# Altium schematic sheet Interface.SchDoc(Interface)
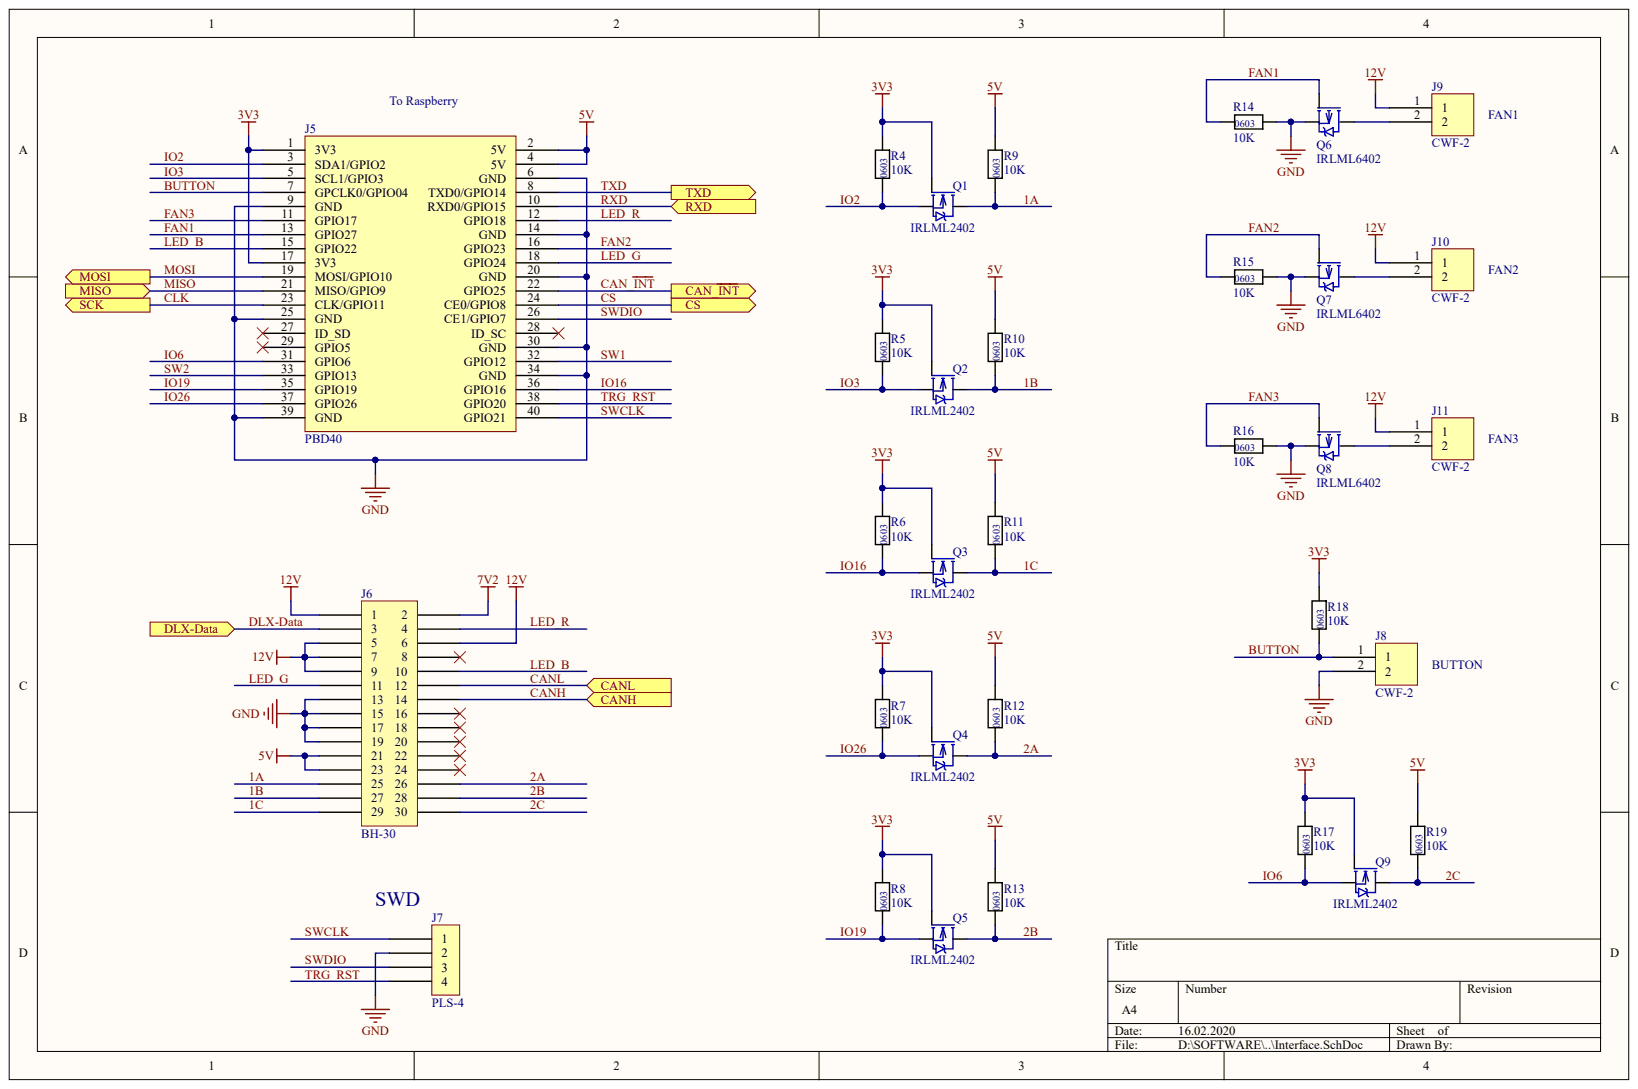
[diagram p0: Interface.SchDoc]

## Parts
J5  PBD40
J6  BH-30
J7  PLS-4
J8  CWF-2
J9  CWF-2
J10  CWF-2
J11  CWF-2
Q1  IRLML2402
Q2  IRLML2402
Q3  IRLML2402
Q4  IRLML2402
Q5  IRLML2402
Q6  IRLML6402
Q7  IRLML6402
Q8  IRLML6402
Q9  IRLML2402
R4  10K
R5  10K
R6  10K
R7  10K
R8  10K
R9  10K
R10  10K
R11  10K
R12  10K
R13  10K
R14  10K
R15  10K
R16  10K
R17  10K
R18  10K
R19  10K

## Ports
CAN_~{INT}, CANH, CANL, CS, DLX-DATA, MISO, MOSI, RXD, SCK, TXD

## Nets
3V3: J5.1(3V3) J5.17(3V3) Q1.1 Q2.1 Q3.1 Q4.1 Q5.1 Q9.1 R4.2 R5.2 R6.2 R7.2 R8.2 R17.2 R18.2
5V: J5.2(5V) J5.4(5V) J6.21 J6.23 R9.2 R10.2 R11.2 R12.2 R13.2 R19.2
7V2: J6.2
12V: J6.1 J6.5 J6.6 J6.7 J6.9 J9.1 J10.1 J11.1
GND: J5.6(GND) J5.9(GND) J5.14(GND) J5.20(GND) J5.25(GND) J5.30(GND) J5.34(GND) J5.39(GND) J6.13 J6.15 J6.17 J6.19 J7.2 J8.2 Q6.2 Q7.2 Q8.2 R14.2 R15.2 R16.2
1A: J6.25 Q1.3 R9.1
1B: J6.27 Q2.3 R10.1
1C: J6.29 Q3.3 R11.1
2A: J6.26 Q4.3 R12.1
2B: J6.28 Q5.3 R13.1
2C: J6.30 Q9.3 R19.1
BUTTON: J5.7(GPCLK0/GPIO04) J8.1 R18.1
CAN_~{INT}: J5.22(GPIO25) ; port CAN_~{INT}
CANH: J6.14 ; port CANH
CANL: J6.12 ; port CANL
CLK: J5.23(CLK/GPIO11) ; port SCK
CS: J5.24(CE0/GPIO8) ; port CS
DLX-DATA: J6.3 ; port DLX-DATA
FAN1: J5.13(GPIO27) Q6.1 R14.1
FAN2: J5.16(GPIO23) Q7.1 R15.1
FAN3: J5.11(GPIO17) Q8.1 R16.1
IO2: J5.3(SDA1/GPIO2) Q1.2 R4.1
IO3: J5.5(SCL1/GPIO3) Q2.2 R5.1
IO6: J5.31(GPIO6) Q9.2 R17.1
IO16: J5.36(GPIO16) Q3.2 R6.1
IO19: J5.35(GPIO19) Q5.2 R8.1
IO26: J5.37(GPIO26) Q4.2 R7.1
LED_B: J5.15(GPIO22) J6.10
LED_G: J5.18(GPIO24) J6.11
LED_R: J5.12(GPIO18) J6.4
MISO: J5.21(MISO/GPIO9) ; port MISO
MOSI: J5.19(MOSI/GPIO10) ; port MOSI
RXD: J5.10(RXD0/GPIO15) ; port RXD
SW1: J5.32(GPIO12)
SW2: J5.33(GPIO13)
SWCLK: J5.40(GPIO21) J7.1
SWDIO: J5.26(CE1/GPIO7) J7.3
TRG_RST: J5.38(GPIO20) J7.4
TXD: J5.8(TXD0/GPIO14) ; port TXD
NetJ9_2: J9.2 Q6.3
NetJ10_2: J10.2 Q7.3
NetJ11_2: J11.2 Q8.3

Not connected: J5 27(ID_SD) 28(ID_SC) 29(GPIO5); J6 8 16 18 20 22 24
